# Altium schematic sheet WiFi.SchDoc
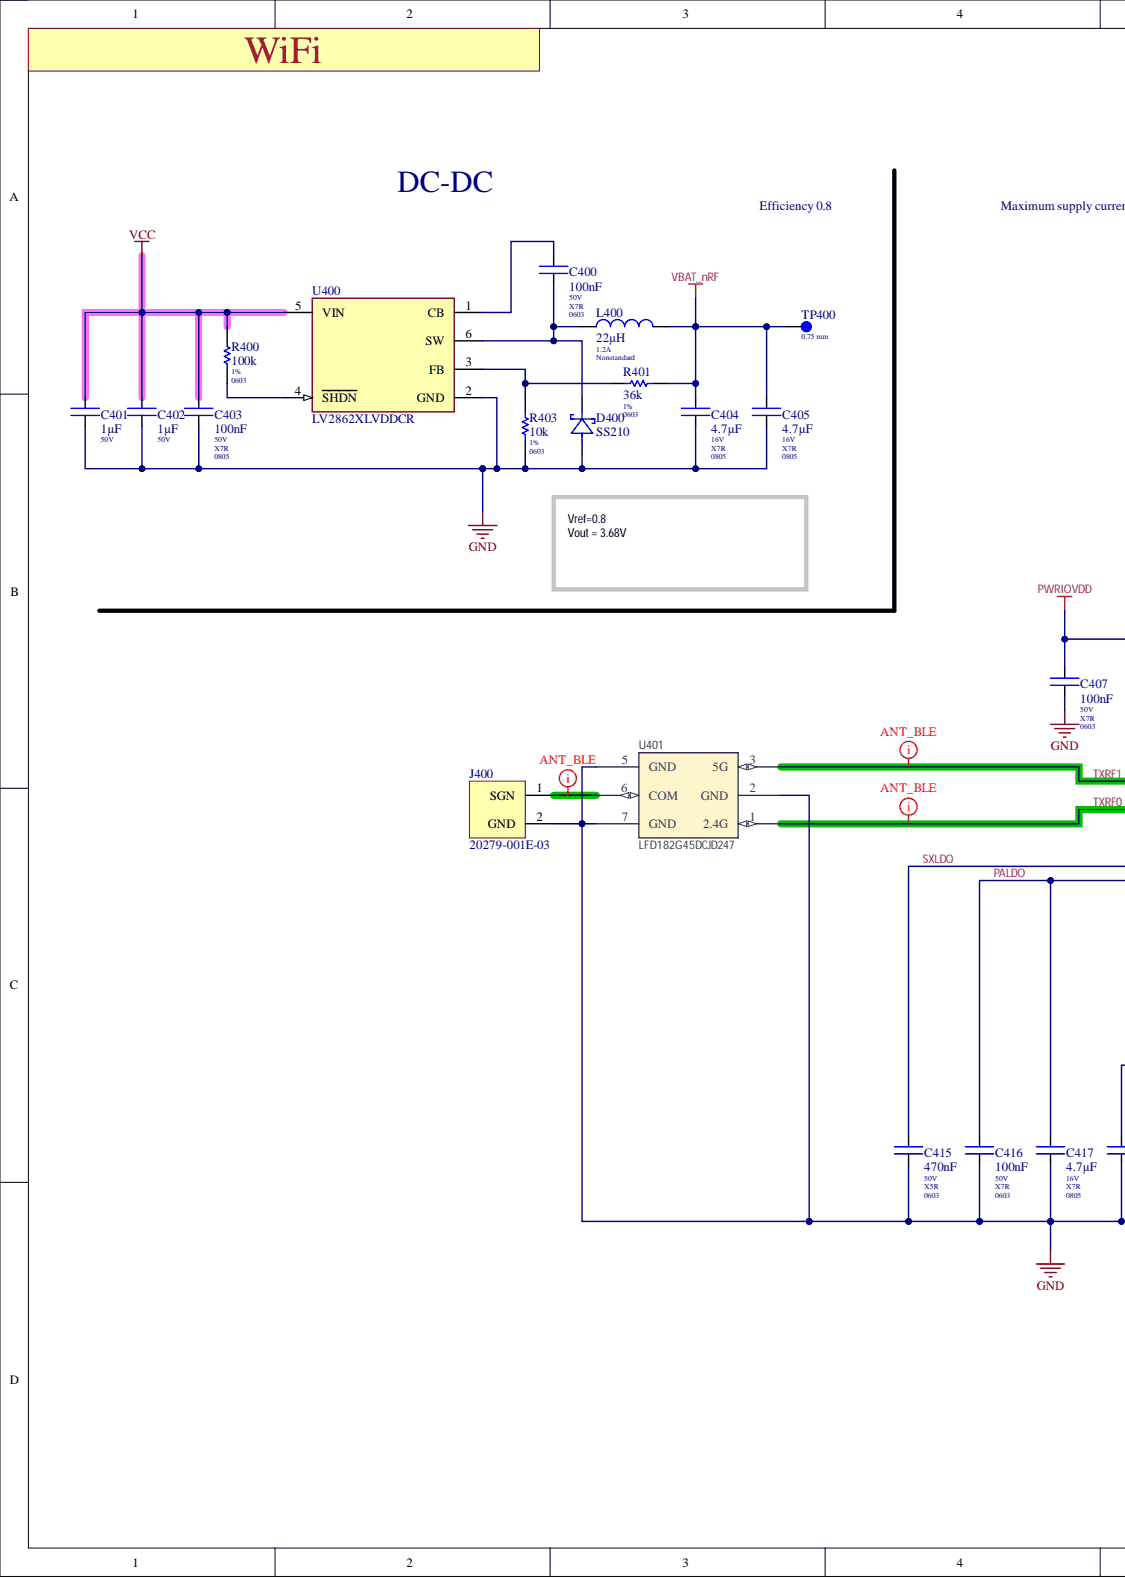
[diagram p0: WiFi.SchDoc, left]
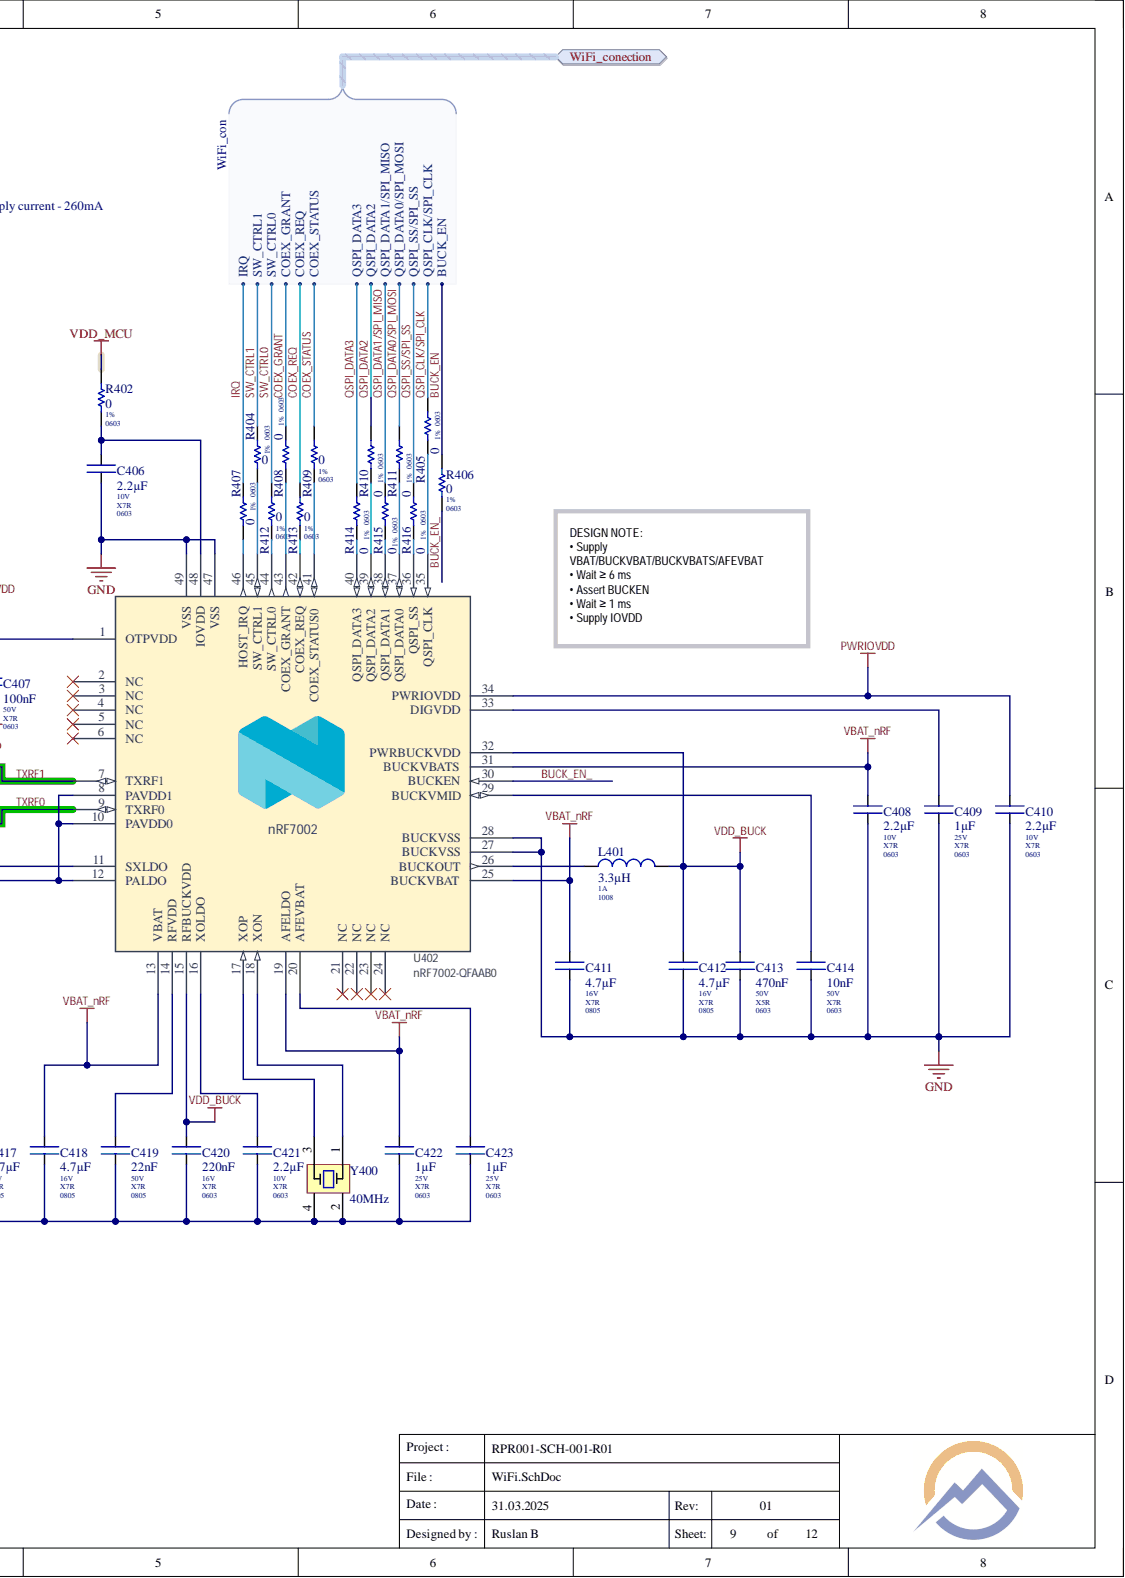
[diagram p1: WiFi.SchDoc, right]

## Parts
C400  value 100nF  Voltage Rating: 50V  [B_Cap_0603_KEM]  (hidden comment: GCM188R71H104KA57D)
C401  value 1µF  Voltage Rating: 50V  [B_Cap_0805_KEM]  (hidden comment: CL21B105KBFNNNG)
C402  value 1µF  Voltage Rating: 50V  [B_Cap_0805_KEM]  (hidden comment: CL21B105KBFNNNG)
C403  value 100nF  Case/Package: 0805  Dielectric: X7R  Voltage Rating: 50V  [B_Cap_0805_KEM]  (hidden comment: CC0805KRX7R9BB104)
C404  value 4.7µF  Case/Package: 0805  Dielectric: X7R  Voltage Rating: 16V  [B_Cap_0805_KEM]  (hidden comment: GRM21BR71C475KE51L)
C405  value 4.7µF  Case/Package: 0805  Dielectric: X7R  Voltage Rating: 16V  [B_Cap_0805_KEM]  (hidden comment: GRM21BR71C475KE51L)
C406  value 2.2µF  Case/Package: 0603  Dielectric: X7R  Voltage Rating: 10V  [B_Cap_0603_KEM]  (hidden comment: CL10B225KP8NNNC)
C407  value 100nF  Case/Package: 0603  Dielectric: X7R  Voltage Rating: 50V  [B_Cap_0603_KEM]  (hidden comment: GCM188R71H104KA57D)
C408  value 2.2µF  Case/Package: 0603  Dielectric: X7R  Voltage Rating: 10V  [B_Cap_0603_KEM]  (hidden comment: CL10B225KP8NNNC)
C409  value 1µF  Case/Package: 0603  Dielectric: X7R  Voltage Rating: 25V  [B_Cap_0603_KEM]  (hidden comment: CL10B105KA8NNNC)
C410  value 2.2µF  Case/Package: 0603  Dielectric: X7R  Voltage Rating: 10V  [B_Cap_0603_KEM]  (hidden comment: CL10B225KP8NNNC)
C411  value 4.7µF  Case/Package: 0805  Dielectric: X7R  Voltage Rating: 16V  [B_Cap_0805_KEM]  (hidden comment: GRM21BR71C475KE51L)
C412  value 4.7µF  Case/Package: 0805  Dielectric: X7R  Voltage Rating: 16V  [B_Cap_0805_KEM]  (hidden comment: GRM21BR71C475KE51L)
C413  value 470nF  Case/Package: 0603  Dielectric: X5R  Voltage Rating: 50V  [B_Cap_0603_KEM]  (hidden comment: CL10A474KB8NNNC)
C414  value 10nF  Case/Package: 0603  Dielectric: X7R  Voltage Rating: 50V  [B_Cap_0603_KEM]  (hidden comment: CC0603MRX7R9BB103)
C415  value 470nF  Case/Package: 0603  Dielectric: X5R  Voltage Rating: 50V  [B_Cap_0603_KEM]  (hidden comment: CL10A474KB8NNNC)
C416  value 100nF  Case/Package: 0603  Dielectric: X7R  Voltage Rating: 50V  [B_Cap_0603_KEM]  (hidden comment: GCM188R71H104KA57D)
C417  value 4.7µF  Case/Package: 0805  Dielectric: X7R  Voltage Rating: 16V  [B_Cap_0805_KEM]  (hidden comment: GRM21BR71C475KE51L)
C418  value 4.7µF  Case/Package: 0805  Dielectric: X7R  Voltage Rating: 16V  [B_Cap_0805_KEM]  (hidden comment: GRM21BR71C475KE51L)
C419  value 22nF  Case/Package: 0805  Dielectric: X7R  Voltage Rating: 50V  [B_Cap_0805_KEM]  (hidden comment: CC0805KRX7R9BB223)
C420  value 220nF  Case/Package: 0603  Dielectric: X7R  Voltage Rating: 16V  [B_Cap_0603_KEM]  (hidden comment: C0603C224K4RAC7411)
C421  value 2.2µF  Dielectric: X7R  Voltage Rating: 10V  [B_Cap_0603_KEM]  (hidden comment: CL10B225KP8NNNC)
C422  value 1µF  Case/Package: 0603  Dielectric: X7R  Voltage Rating: 25V  [B_Cap_0603_KEM]  (hidden comment: CL10B105KA8NNNC)
C423  value 1µF  Case/Package: 0603  Dielectric: X7R  Voltage Rating: 25V  [B_Cap_0603_KEM]  (hidden comment: CL10B105KA8NNNC)
D400  SS210  [DO-214AA, SMB]
J400  20279-001E-03  [20279-001E-03]
L400  value 22µH  Current Rating: 1.2A  [CDRH6D28NP]  (hidden comment: CDRH6D28NP-220NC)
L401  value 3.3µH  Case/Package: 1008  Current Rating: 1A  [FP-LQM2HPN_G0-IPC_B]  (hidden comment: LQM2HPN3R3MGCL)
R400  value 100k  Case/Package: 0603  Tolerance: 1%  [Res_0603_YAG]  (hidden comment: RMCF0603FT100K)
R401  value 36k  [Res_0603_YAG]  (hidden comment: RC0603FR-0736KL)
R402  value 0  Case/Package: 0603  Tolerance: 1%  [Res_0603_YAG]  (hidden comment: RC0603FR-070RL)
R403  value 10k  Case/Package: 0603  Tolerance: 1%  [Res_0603_YAG]  (hidden comment: RC0603FR-0710KL)
R404  value 0  Case/Package: 0603  Tolerance: 1%  [Res_0603_YAG]  (hidden comment: RC0603FR-070RL)
R405  value 0  Case/Package: 0603  Tolerance: 1%  [Res_0603_YAG]  (hidden comment: RC0603FR-070RL)
R406  value 0  Case/Package: 0603  Tolerance: 1%  [Res_0603_YAG]  (hidden comment: RC0603FR-070RL)
R407  value 0  Case/Package: 0603  Tolerance: 1%  [Res_0603_YAG]  (hidden comment: RC0603FR-070RL)
R408  value 0  Case/Package: 0603  Tolerance: 1%  [Res_0603_YAG]  (hidden comment: RC0603FR-070RL)
R409  value 0  Case/Package: 0603  Tolerance: 1%  [Res_0603_YAG]  (hidden comment: RC0603FR-070RL)
R410  value 0  Case/Package: 0603  Tolerance: 1%  [Res_0603_YAG]  (hidden comment: RC0603FR-070RL)
R411  value 0  Case/Package: 0603  Tolerance: 1%  [Res_0603_YAG]  (hidden comment: RC0603FR-070RL)
R412  value 0  Case/Package: 0603  Tolerance: 1%  [Res_0603_YAG]  (hidden comment: RC0603FR-070RL)
R413  value 0  Case/Package: 0603  Tolerance: 1%  [Res_0603_YAG]  (hidden comment: RC0603FR-070RL)
R414  value 0  Case/Package: 0603  Tolerance: 1%  [Res_0603_YAG]  (hidden comment: RC0603FR-070RL)
R415  value 0  Case/Package: 0603  Tolerance: 1%  [Res_0603_YAG]  (hidden comment: RC0603FR-070RL)
R416  value 0  Case/Package: 0603  Tolerance: 1%  [Res_0603_YAG]  (hidden comment: RC0603FR-070RL)
TP400  0.75 mm  [0.75mm]  (no BOM)
U400  LV2862XLVDDCR  [SOT-23-6]
U401  LFD182G45DCJD247  [CAF-50P160X80-6N]
U402  nRF7002-QFAAB0  [QFN48N]
Y400  Frequency: 40MHz  [1612_crystal]  (hidden comment: 830069527)

## Ports
WiFi_conection, WiFi_conection.BUCK_EN, WiFi_conection.COEX_GRANT, WiFi_conection.COEX_REQ, WiFi_conection.COEX_STATUS, WiFi_conection.IRQ, WiFi_conection.QSPI_CLK/SPI_CLK, WiFi_conection.QSPI_DATA0/SPI_MOSI, WiFi_conection.QSPI_DATA1/SPI_MISO, WiFi_conection.QSPI_DATA2, WiFi_conection.QSPI_DATA3, WiFi_conection.QSPI_SS/SPI_SS, WiFi_conection.SW_CTRL0, WiFi_conection.SW_CTRL1

## Nets
GND: C401.2 C402.2 C403.1 C404.1 C405.1 C406.1 C407.1 C408.1 C409.1 C410.1 C411.1 C412.1 C413.1 C414.1 C415.1 C416.1 C417.1 C418.1 C419.1 C420.2 C421.1 C422.1 C423.1 D400.2 J400.2 R403.1 U400.2(GND) U401.2(GND) U401.5(GND) U401.7(GND) U402.27(BUCKVSS) U402.28(BUCKVSS) U402.47(VSS) U402.49(VSS) Y400.2 Y400.4
PWRIOVDD: C407.2 C410.2 U402.1(OTPVDD) U402.34(PWRIOVDD)
VBAT_nRF: C404.2 C405.2 C408.2 C411.2 C418.2 C422.2 L400.2 R401.2 TP400.1 U402.13(VBAT) U402.19(AFELDO) U402.25(BUCKVBAT) U402.31(BUCKVBATS)
VCC: C401.1 C402.1 C403.2 R400.2 U400.5(VIN)
VDD_BUCK: C412.2 C413.2 C420.1 L401.2 U402.15(RFBUCKVDD) U402.32(PWRBUCKVDD)
VDD_MCU: R402.2
BUCK_EN: R406.2 ; port WiFi_conection
BUCK_EN_: R406.1 U402.30(BUCKEN)
COEX_GRANT: R408.2 ; port WiFi_conection
COEX_REQ: R413.2 ; port WiFi_conection
COEX_STATUS: R409.2 ; port WiFi_conection
IRQ: R407.2 ; port WiFi_conection
PALDO: C416.2 C417.2 U402.8(PAVDD1) U402.10(PAVDD0) U402.12(PALDO)
QSPI_CLK/SPI_CLK: R405.2 ; port WiFi_conection
QSPI_DATA0/SPI_MOSI: R411.2 ; port WiFi_conection
QSPI_DATA1/SPI_MISO: R415.2 ; port WiFi_conection
QSPI_DATA2: R410.2 ; port WiFi_conection
QSPI_DATA3: R414.2 ; port WiFi_conection
QSPI_SS/SPI_SS: R416.2 ; port WiFi_conection
SW_CTRL0: R412.2 ; port WiFi_conection
SW_CTRL1: R404.2 ; port WiFi_conection
SXLDO: C415.2 U402.11(SXLDO)
TXRF0: U401.1(2.4G) U402.9(TXRF0)
TXRF1: U401.3(5G) U402.7(TXRF1)
NetC400_1: C400.1 D400.1 L400.1 U400.6(SW)
NetC400_2: C400.2 U400.1(CB)
NetC406_2: C406.2 R402.1 U402.48(IOVDD)
NetC409_2: C409.2 U402.33(DIGVDD)
NetC414_2: C414.2 U402.29(BUCKVMID)
NetC419_2: C419.2 U402.14(RFVDD)
NetC421_2: C421.2 U402.16(XOLDO)
NetC423_2: C423.2 U402.20(AFEVBAT)
NetJ400_1: J400.1 U401.6(COM)
NetL401_1: L401.1 U402.26(BUCKOUT)
NetR400_1: R400.1 U400.4(SHDN)
NetR401_1: R401.1 R403.2 U400.3(FB)
NetR404_1: R404.1 U402.45(SW_CTRL1)
NetR405_1: R405.1 U402.35(QSPI_CLK)
NetR407_1: R407.1 U402.46(HOST_IRQ)
NetR408_1: R408.1 U402.43(COEX_GRANT)
NetR409_1: R409.1 U402.41(COEX_STATUS0)
NetR410_1: R410.1 U402.39(QSPI_DATA2)
NetR411_1: R411.1 U402.37(QSPI_DATA0)
NetR412_1: R412.1 U402.44(SW_CTRL0)
NetR413_1: R413.1 U402.42(COEX_REQ)
NetR414_1: R414.1 U402.40(QSPI_DATA3)
NetR415_1: R415.1 U402.38(QSPI_DATA1)
NetR416_1: R416.1 U402.36(QSPI_SS)
NetU402_17: U402.17(XOP) Y400.3
NetU402_18: U402.18(XON) Y400.1

Not connected: U402 2(NC) 3(NC) 4(NC) 5(NC) 6(NC) 21(NC) 22(NC) 23(NC) 24(NC)

## Library data of the parts
C400 C407 C416 = CMP-CAP-CER-00027-13: CAP CER 0.1UF 50V X7R 0603; Manufacturer 1: Murata; Tolerance: ±10%; Component Kind: Standard; ComponentLink1Description: Datasheet; ComponentLink1URL: https://search.murata.co.jp/Ceramy/image/img/A01X/G101/ENG/GCM188R71H104KA57-01.pdf; Library Name: <Company> Server; Manufacturer Part Number 1: GCM188R71H104KA57D
  C400: Case/Package: 0603; Dielectric: X7R
C401 C402 = CMP-CAP-CER-00135-12: CAP CER 1UF 50V X7R 0805; Manufacturer 1: Samsung; Tolerance: ±10%; Component Kind: Standard; ComponentLink1Description: Datasheet; ComponentLink1URL: http://www.samsungsem.com/kr/support/product-search/mlcc/CL21B105KBFNNNG.jsp; Library Name: <Company> Server; Manufacturer Part Number 1: CL21B105KBFNNNG
C403 = CMP-CAP-CER-00029-14: Multilayer Ceramic Capacitor, 0.1 uF, 50 V, ï¿½ 10%, X7R, 0805 [2012 Metric]; Manufacturer 1: YAGEO; Component Kind: Standard; Library Name: <Company> Server; Manufacturer Part Number 1: CC0805KRX7R9BB104; Tolerance: 10%
C404 C405 C411 C412 C417 C418 = CMP-CAP-CER-00204-11: CAP CER 4.7UF 16V X7R 0805; Manufacturer 1: Murata; Tolerance: ±10%; Component Kind: Standard; ComponentLink1Description: Datasheet; ComponentLink1URL: https://search.murata.co.jp/Ceramy/image/img/A01X/G101/ENG/GRM21BR71C475KE51-01.pdf; Library Name: <Company> Server; Manufacturer Part Number 1: GRM21BR71C475KE51L
C406 C408 C410 C421 = CMP-CAP-CER-00144-13: CAP CER 2.2UF 10V X7R 0603; Manufacturer 1: Samsung; Tolerance: ±10%; Component Kind: Standard; ComponentLink1Description: Datasheet; ComponentLink1URL: http://www.samsungsem.com/kr/support/product-search/mlcc/CL10B225KP8NNNC.jsp; Library Name: <Company> Server; Manufacturer Part Number 1: CL10B225KP8NNNC
  C421: Case/Package: 0603
C409 C422 C423 = CMP-CAP-CER-00132-13: CL10B105KA8NNNC Samsung Ceramic Capacitor MLCC 1uF10% 25V X7R SMD 0603 (1608 Metric) RoHS; Manufacturer 1: Samsung Electro-Mechanics; Tolerance: 10%; Component Kind: Standard; Library Name: <Company> Server; Manufacturer Part Number 1: CL10B105KA8NNNC
C413 C415 = CMP-CAP-CER-00043-13: CAP CER 0.47UF 50V X5R 0603; Manufacturer 1: Samsung; Tolerance: ±10%; Component Kind: Standard; ComponentLink1Description: Datasheet; ComponentLink1URL: https://www.samsungsem.com/kr/support/product-search/mlcc/CL10A474KB8NNNC.jsp; Library Name: <Company> Server; Manufacturer Part Number 1: CL10A474KB8NNNC
C414 = CMP-CAP-CER-00261-3: 0603 10 nF 50 V ±20% Tolerance X7R SMT Multilayer Ceramic Capacitor; Manufacturer 1: Yageo; Component Kind: Standard; Library Name: <Company> Server; Manufacturer Part Number 1: CC0603MRX7R9BB103; Tolerance: 20%
C419 = CMP-CAP-CER-00007-13: CAP CER 0.022UF 50V X7R 0805; Manufacturer 1: Yageo; Tolerance: ±10%; Component Kind: Standard; ComponentLink1Description: Datasheet; ComponentLink1URL: https://www.yageo.com/upload/media/product/productsearch/datasheet/mlcc/UPY-GPHC_X7R_6.3V-to-50V_18.pdf; Library Name: <Company> Server; Manufacturer Part Number 1: CC0805KRX7R9BB223
C420 = CMP-CAP-CER-00035-13: CAP CER 0.22UF 16V X7R 0603; Manufacturer 1: KEMET; Tolerance: ±10%; Component Kind: Standard; ComponentLink1Description: Datasheet; ComponentLink1URL: https://api.kemet.com/component-edge/download/datasheet/C0603C224K4RAC7411.pdf; Library Name: <Company> Server; Manufacturer Part Number 1: C0603C224K4RAC7411
D400 = CMP-DIO-SCH-00037-8: DIODE SCHOTTKY 100V 2A SMA; Manufacturer 1: Taiwan Semiconductor; Forward Voltage: 850mV; Max Reverse Current: 100µA; Average Rectified Current: 2A; Case/Package: DO-214AA; Component Kind: Standard; ComponentLink1Description: Datasheet; ComponentLink1URL: http://www.smc-diodes.com/propdf/SS22A%20THRU%20SS225A%20N1816%20REV.A.pdf; Forward Current: 2A; Library Name: <Company> Server; Manufacturer Part Number 1: SS210; Max Reverse Voltage (DC): 100V
J400 = CMP-CON-RF-00010-1: MHF Series Vertical Receptacle Micro Coaxial Connector; Manufacturer 1: I-PEX; Impedance: 50Ohm; Max Frequency: 9GHz; Component Kind: Standard; Library Name: <Company> Server; Manufacturer Part Number 1: 20279-001E-03
L400 = CMP-IND-00067-9: FIXED IND 22UH 1.2A 128 MOHM SMD; Manufacturer 1: Sumida; DC Resistance (DCR): 0.128; Shielding: Shielded; Tolerance: 30%; Case/Package: Nonstandard; Component Kind: Standard; ComponentLink1Description: Datasheet; ComponentLink1URL: http://products.sumida.com/products/pdf/CDRH6D28.pdf; Library Name: <Company> Server; Manufacturer Part Number 1: CDRH6D28NP-220NC
L401 = CMP-IND-00155-2: Inductor Power Chip Shielded Multi-Layer 3.3uH 20% 1MHz Ferrite 1A 0.2Ohm DCR 1008 T/R; Manufacturer 1: Taiyo Yuden; Manufacturer Part Number 1: BRC2012T4R7MD; DC Resistance (DCR): 0.2; Self Resonant Frequency: 30MHz; Component Kind: Standard; Library Name: <Company> Server; Shielding: Shielded; Tolerance: 20%
R400 = CMP-RES-SMD-00047-11: RES 100K OHM 1% 1/10W 0603; Manufacturer 1: Stackpole Electronics; Temperature Coefficient: ±100ppm/℃; Component Kind: Standard; ComponentLink1Description: Datasheet; ComponentLink1URL: https://www.seielect.com/catalog/sei-rmcf_rmcp.pdf; Library Name: <Company> Server; Manufacturer Part Number 1: RMCF0603FT100K
R401 = CMP-RES-SMD-00313-9: RES SMD 36K OHM 1% 1/10W 0603; Manufacturer 1: Yageo; Temperature Coefficient: ±100ppm/℃; Case/Package: 0603; Component Kind: Standard; ComponentLink1Description: Datasheet; ComponentLink1URL: https://www.yageo.com/upload/media/product/productsearch/datasheet/rchip/PYu-RC_Group_51_RoHS_L_11.pdf; Library Name: <Company> Server; Manufacturer Part Number 1: RC0603FR-0736KL; Tolerance: 1%
R402 R404-R416 = CMP-RES-SMD-00136-9: RES SMD 0 OHM JUMPER 1/10W 0603; Manufacturer 1: Yageo; Temperature Coefficient: ±100ppm/℃; Component Kind: Standard; ComponentLink1Description: Datasheet; ComponentLink1URL: https://www.yageo.com/upload/media/product/productsearch/datasheet/rchip/PYu-RC_Group_51_RoHS_L_11.pdf; Library Name: <Company> Server; Manufacturer Part Number 1: RC0603FR-070RL
R403 = CMP-RES-SMD-00183-9: RES SMD 10K OHM 1% 1/10W 0603; Manufacturer 1: Yageo; Temperature Coefficient: ±100ppm/℃; Component Kind: Standard; ComponentLink1Description: Datasheet; ComponentLink1URL: https://www.yageo.com/upload/media/product/productsearch/datasheet/rchip/PYu-RC_Group_51_RoHS_L_10.pdf; Library Name: <Company> Server; Manufacturer Part Number 1: RC0603FR-0710KL
TP400 = CMP-MF-TP-00003-4: Test point 0.75 mm; Library Name: <Company> Server; Size: 0.75 mm
U400 = CMP-POW-VOLR-SW-00041-4: 4.5-V to 60-V, 600-mA buck converter in ultra-small SOT23 package 6-SOT-23-THIN -40 to 125; Manufacturer 1: Texas Instruments; Max Input Voltage: 60V; Min Input Voltage: 4V; Output Current: 600mA; Output Type: Adjustable; Switching Frequency: 2.1MHz; Synchronous Rectifier: No; Case/Package: SOT-23-6 Thin, TSOT-23-6; Component Kind: Standard; Library Name: <Company> Server; Manufacturer Part Number 1: LV2862XLVDDCR; Output Voltage: 60V
U401 = CMP-2155-000000-3: Signal Conditioning 2.4GHz and 5GHz Diplexer; Manufacturer: Murata; Pad Count: 6; Component Class: Filters; Component Kind: Standard; Component Type: Standard; Design Item ID: LFD182G45DCJD247; Footprint Doc: CAF; Library Name: <Company> Server; Manufacturer 1: Murata; Manufacturer Part Number: LFD182G45DCJD247; Manufacturer Part Number 1: LFD182G45DCJD247; Part Number: LFD182G45DCJD247; Pin Count: 6; Value: LFD182G45DCJD247; Source: nrf7002_qfaa_config1.SCHLIB
U402 = CMP-2155-000001-8: Wi-fi Dual Band Companion chip; Manufacturer: Nordic Semiconductor; Pad Count: 49; Case/Package: 48-VFQFN Exposed Pad; Component Class: Nordic_Devices; Component Kind: Standard; Component Type: Standard; Design Item ID: nRF7002-QFAAB0; Footprint Doc: QFN-48; Library Name: <Company> Server; Manufacturer 1: Nordic Semiconductor; Manufacturer Part Number: nRF7002-QFAAB0; Manufacturer Part Number 1: nRF7002-QFAAB0; Part Number: nRF7002-QFAAB0; Pin Count: 49; Value: nRF7002-QFAA; Source: nrf7002_qfaa_config1.SCHLIB
Y400 = CMP-CRaO-CR-00034-1: WE-XTAL Quartz Crystal 40MHz 8pF; Manufacturer 1: Würth Elektronik; ESR (Equivalent Series Resistance): 100; Frequency Stability: 15ppm; Case/Package: SMD/SMT; Component Kind: Standard; Library Name: <Company> Server; Load Capacitance: 8pF; Manufacturer Part Number 1: 830069527; Mount: Surface Mount; RoHS Compliant: Yes
